# Altium schematic sheet carrier.SchDoc
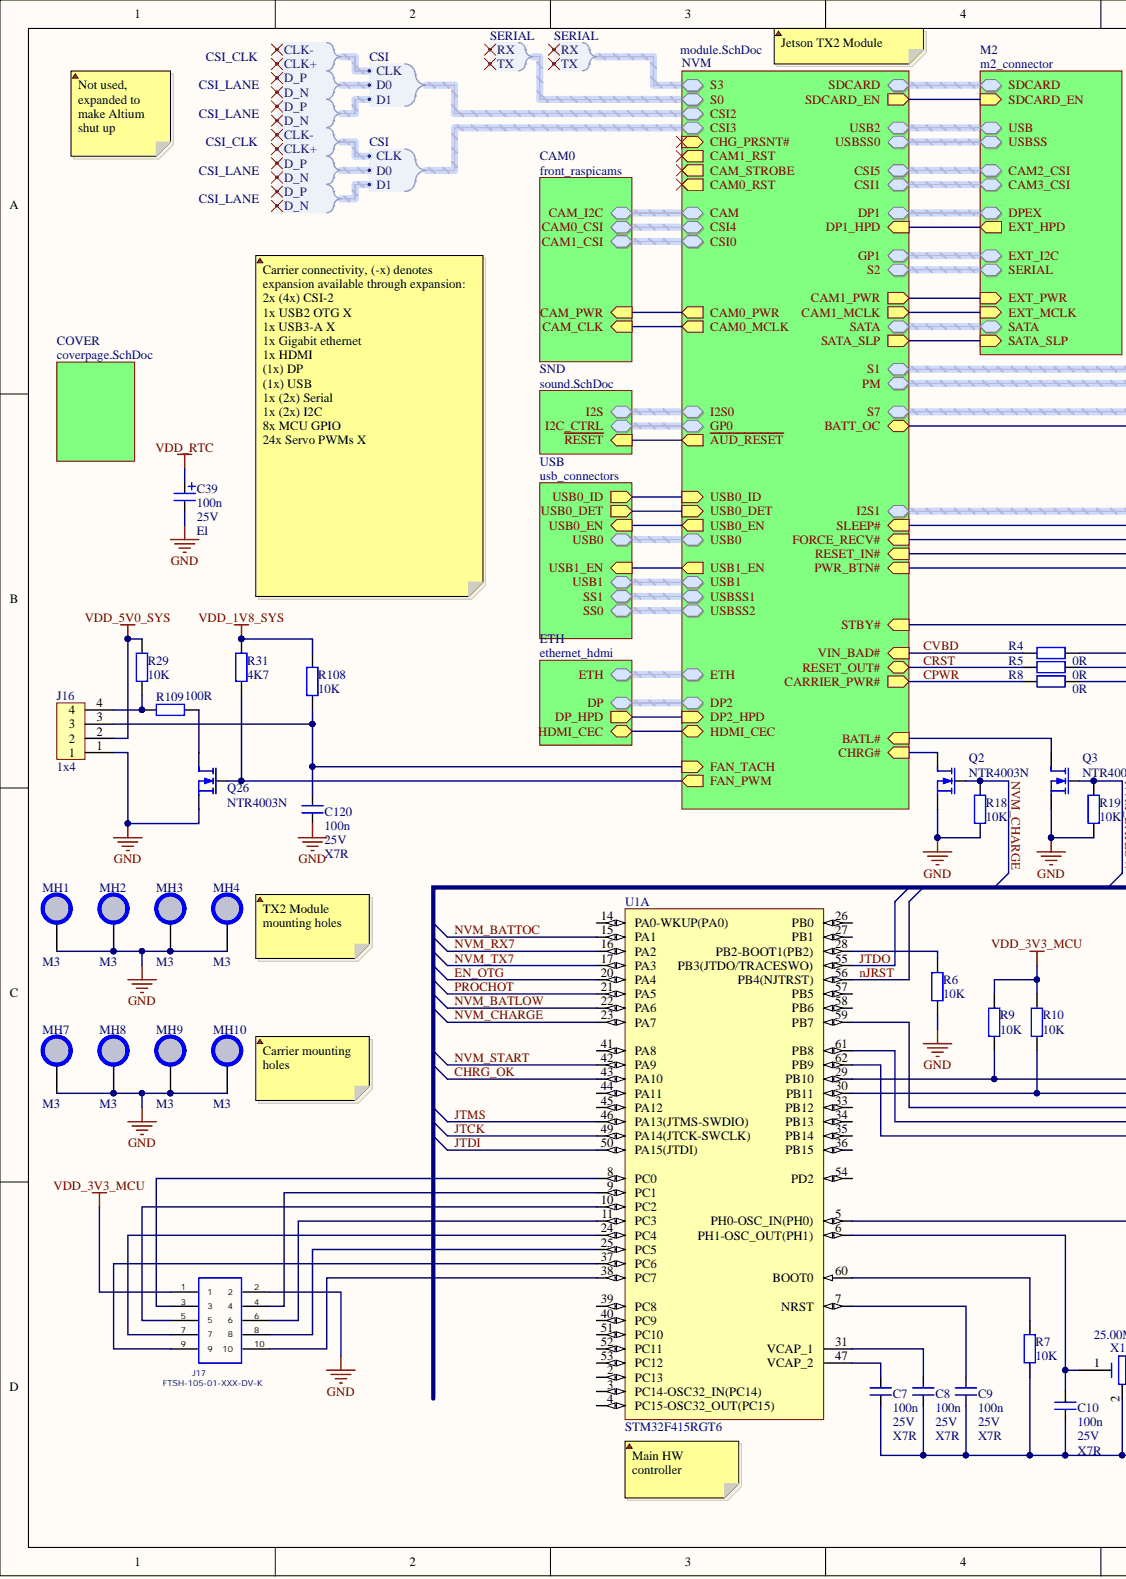
[diagram p0: carrier.SchDoc, left]
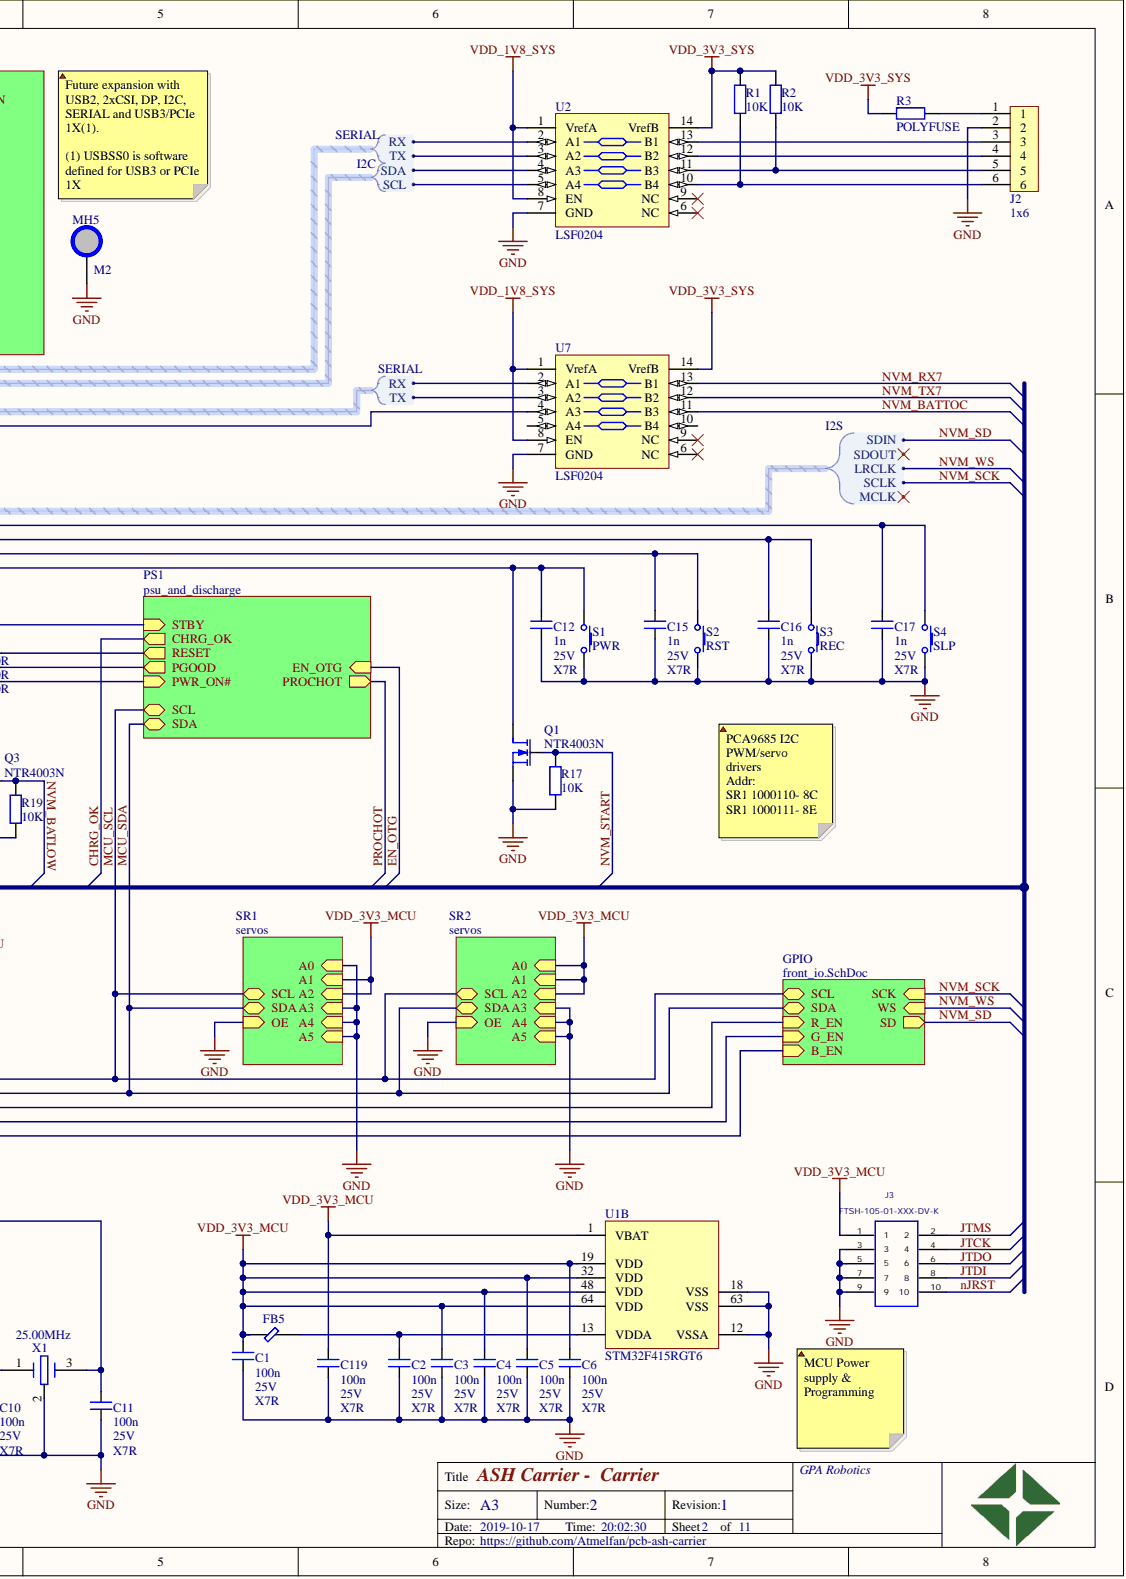
[diagram p1: carrier.SchDoc, right]

## Parts
C1  value 100n  Type: X7R  Voltage: 25V  [C1005[0402]]  (hidden comment: cap)
C2  value 100n  Type: X7R  Voltage: 25V  [C1005[0402]]  (hidden comment: cap)
C3  value 100n  Type: X7R  Voltage: 25V  [C1005[0402]]  (hidden comment: cap)
C4  value 100n  Type: X7R  Voltage: 25V  [C1005[0402]]  (hidden comment: cap)
C5  value 100n  Type: X7R  Voltage: 25V  [C1005[0402]]  (hidden comment: cap)
C6  value 100n  Type: X7R  Voltage: 25V  [C1005[0402]]  (hidden comment: cap)
C7  value 100n  Type: X7R  Voltage: 25V  [C1005[0402]]  (hidden comment: cap)
C8  value 100n  Type: X7R  Voltage: 25V  [C1005[0402]]  (hidden comment: cap)
C9  value 100n  Type: X7R  Voltage: 25V  [C1005[0402]]  (hidden comment: cap)
C10  value 100n  Type: X7R  Voltage: 25V  [C1005[0402]]  (hidden comment: cap)
C11  value 100n  Type: X7R  Voltage: 25V  [C1005[0402]]  (hidden comment: cap)
C12  value 1n  Voltage: 25V  [C1005[0402]]  (hidden comment: cap)
C15  value 1n  Voltage: 25V  [C1005[0402]]  (hidden comment: cap)
C16  value 1n  Voltage: 25V  [C1005[0402]]  (hidden comment: cap)
C17  value 1n  Voltage: 25V  [C1005[0402]]  (hidden comment: cap)
C39  value 100n  Type: El  Voltage: 25V  [SC_DSK-3R3H224U-HL]  (hidden comment: pcap)
C119  value 100n  Type: X7R  Voltage: 25V  [C1005[0402]]  (hidden comment: cap)
C120  value 100n  Type: X7R  Voltage: 25V  [C1005[0402]]  (hidden comment: cap)
FB5  2506036007Y3  [FBC1608X07N]  (value not drawn)
J2  1x6  [hdr_100mil_1x6]
J3  FTSH-105-01-XXX-DV-K  [FTSH-105-01-XXX-DV-K]
J16  1x4  [molex_picoblade_1x4]
J17  FTSH-105-01-XXX-DV-K  [FTSH-105-01-XXX-DV-K]
MH1  M3  [m3_pan_tight_round]
MH2  M3  [m3_pan_tight_round]
MH3  M3  [m3_pan_tight_round]
MH4  M3  [m3_pan_tight_round]
MH5  M2  [m2_pan_tight_round]
MH7  M3  [m3_pan_tight_round]
MH8  M3  [m3_pan_tight_round]
MH9  M3  [m3_pan_tight_round]
MH10  M3  [m3_pan_tight_round]
Q1  NTR4003N  [NCH_SOT95P237X110-3N]
Q2  NTR4003N  [NCH_SOT95P237X110-3N]
Q3  NTR4003N  [NCH_SOT95P237X110-3N]
Q26  NTR4003N  [NCH_SOT95P237X110-3N]
R1  value 10K  [R1005[0402]]  (hidden comment: res)
R2  value 10K  [R1005[0402]]  (hidden comment: res)
R3  value POLYFUSE  [R1005[0402]]  (hidden comment: res)
R4  value 0R  [R1005[0402]]  (hidden comment: res)
R5  value 0R  [R1005[0402]]  (hidden comment: res)
R6  value 10K  [R1005[0402]]  (hidden comment: res)
R7  value 10K  [R1005[0402]]  (hidden comment: res)
R8  value 0R  [R1005[0402]]  (hidden comment: res)
R9  value 10K  [R1005[0402]]  (hidden comment: res)
R10  value 10K  [R1005[0402]]  (hidden comment: res)
R17  value 10K  [R1005[0402]]  (hidden comment: res)
R18  value 10K  [R1005[0402]]  (hidden comment: res)
R19  value 10K  [R1005[0402]]  (hidden comment: res)
R29  value 10K  [R1005[0402]]  (hidden comment: res)
R31  value 4K7  [R1005[0402]]  (hidden comment: res)
R108  value 10K  [R1005[0402]]  (hidden comment: res)
R109  value 100R  [R1005[0402]]  (hidden comment: res)
S1  PWR  [SW_6MM_TACT]
S2  RST  [SW_6MM_TACT]
S3  REC  [SW_6MM_TACT]
S4  SLP  [SW_6MM_TACT]
U1  STM32F415RGT6  [STM-LQFP64_N]  (parts A,B)
U2  LSF0204  [TI_TSOP65P640X120-14N]
U7  LSF0204  [TI_TSOP65P640X120-14N]
X1  value 25.00MHz  [FA-238]  (hidden comment: crystal_shielded)

## Nets
GND: C1.2 C2.2 C3.2 C4.2 C5.2 C6.2 C7.2 C8.2 C9.2 C10.2 C11.2 C12.1 C15.1 C16.1 C17.1 C39.2 C119.2 C120.1 J2.2 J3.3 J3.5 J3.7 J3.9 J16.1 J17.2 MH1.1 MH2.1 MH3.1 MH4.1 MH5.1 MH7.1 MH8.1 MH9.1 MH10.1 Q1.S Q2.S Q3.S Q26.S R6.1 R7.1 R17.1 R18.1 R19.1 S1.2 S2.2 S3.2 S4.2 U1.12(VSSA) U1.18(VSS) U1.63(VSS) U2.7(GND) U7.7(GND) X1.2
VDD_1V8_SYS: R31.2 R108.2 U2.1(VrefA) U2.8(EN) U7.1(VrefA) U7.8(EN)
VDD_3V3_MCU: C1.1 C3.1 C4.1 C5.1 C6.1 C119.1 FB5.1 J3.1 J17.1 R9.2 R10.2 U1.1(VBAT) U1.19(VDD) U1.32(VDD) U1.48(VDD) U1.64(VDD)
VDD_3V3_SYS: R1.2 R2.2 R3.2 U2.14(VrefB) U7.14(VrefB)
VDD_5V0_SYS: J16.2 R29.1
VDD_RTC: C39.1
CHRG_OK: U1.43(PA10)
CPWR: R8.1
CRST: R5.1
CVBD: R4.1
EN_OTG: U1.20(PA4)
JTCK: J3.4 U1.49(PA14(JTCK-SWCLK))
JTDI: J3.8 U1.50(PA15(JTDI))
JTDO: J3.6 U1.55(PB3(JTDO/TRACESWO))
JTMS: J3.2 U1.46(PA13(JTMS-SWDIO))
MCU_SCL: R9.1 U1.29(PB10)
MCU_SDA: R10.1 U1.30(PB11)
nJRST: J3.10 U1.56(PB4(NJTRST))
NVM_BATLOW: Q3.G R19.2 U1.22(PA6)
NVM_BATTOC: U1.15(PA1) U7.11(B3)
NVM_CHARGE: Q2.G R18.2 U1.23(PA7)
NVM_RX7: U1.16(PA2) U7.13(B1)
NVM_START: Q1.G R17.2 U1.42(PA9)
NVM_TX7: U1.17(PA3) U7.12(B2)
PROCHOT: U1.21(PA5)
NetC2_1: C2.1 FB5.2 U1.13(VDDA)
NetC7_1: C7.1 U1.47(VCAP_2)
NetC8_1: C8.1 U1.31(VCAP_1)
NetC9_1: C9.1 U1.7(NRST)
NetC10_1: C10.1 U1.6(PH1-OSC_OUT(PH1)) X1.1
NetC11_1: C11.1 U1.5(PH0-OSC_IN(PH0)) X1.3
NetC12_2: C12.2 Q1.D S1.1
NetC15_2: C15.2 S2.1
NetC16_2: C16.2 S3.1
NetC17_2: C17.2 S4.1
NetC120_2: C120.2 J16.3 R108.1
NetJ2_1: J2.1 R3.1
NetJ2_3: J2.3 U2.13(B1)
NetJ2_4: J2.4 U2.12(B2)
NetJ2_5: J2.5 R2.1 U2.11(B3)
NetJ2_6: J2.6 R1.1 U2.10(B4)
NetJ16_4: J16.4 R29.2 R109.1
NetJ17_3: J17.3 U1.8(PC0)
NetJ17_4: J17.4 U1.9(PC1)
NetJ17_5: J17.5 U1.10(PC2)
NetJ17_6: J17.6 U1.11(PC3)
NetJ17_7: J17.7 U1.24(PC4)
NetJ17_8: J17.8 U1.25(PC5)
NetJ17_9: J17.9 U1.37(PC6)
NetJ17_10: J17.10 U1.38(PC7)
NetQ2_D: Q2.D
NetQ3_D: Q3.D
NetQ26_D: Q26.D R109.2
NetQ26_G: Q26.G R31.1
NetR4_2: R4.2
NetR5_2: R5.2
NetR6_2: R6.2 U1.28(PB2-BOOT1(PB2))
NetR7_2: R7.2 U1.60(BOOT0)
NetR8_2: R8.2
NetU1_2: U1.2(PC13)
NetU1_3: U1.3(PC14-OSC32_IN(PC14))
NetU1_4: U1.4(PC15-OSC32_OUT(PC15))
NetU1_14: U1.14(PA0-WKUP(PA0))
NetU1_26: U1.26(PB0)
NetU1_27: U1.27(PB1)
NetU1_33: U1.33(PB12)
NetU1_34: U1.34(PB13)
NetU1_35: U1.35(PB14)
NetU1_36: U1.36(PB15)
NetU1_39: U1.39(PC8)
NetU1_40: U1.40(PC9)
NetU1_41: U1.41(PA8)
NetU1_44: U1.44(PA11)
NetU1_45: U1.45(PA12)
NetU1_51: U1.51(PC10)
NetU1_52: U1.52(PC11)
NetU1_53: U1.53(PC12)
NetU1_54: U1.54(PD2)
NetU1_57: U1.57(PB5)
NetU1_58: U1.58(PB6)
NetU1_59: U1.59(PB7)
NetU1_61: U1.61(PB8)
NetU1_62: U1.62(PB9)
NetU2_2: U2.2(A1)
NetU2_3: U2.3(A2)
NetU2_4: U2.4(A3)
NetU2_5: U2.5(A4)
NetU2_6: U2.6(NC)
NetU2_9: U2.9(NC)
NetU7_2: U7.2(A1)
NetU7_3: U7.3(A2)
NetU7_4: U7.4(A3)
NetU7_5: U7.5(A4)
NetU7_6: U7.6(NC)
NetU7_9: U7.9(NC)
NetU7_10: U7.10(B4)
~{AUD_RESET}:
CAM0_CSI.CLK.CLK+:
CAM0_CSI.CLK.CLK-:
CAM0_CSI.D0.D_N:
CAM0_CSI.D0.D_P:
CAM0_CSI.D1.D_N:
CAM0_CSI.D1.D_P:
CAM0_RST:
CAM1_CSI.CLK.CLK+:
CAM1_CSI.CLK.CLK-:
CAM1_CSI.D0.D_N:
CAM1_CSI.D0.D_P:
CAM1_CSI.D1.D_N:
CAM1_CSI.D1.D_P:
CAM1_RST:
CAM2_CSI.CLK.CLK+:
CAM2_CSI.CLK.CLK-:
CAM2_CSI.D0.D_N:
CAM2_CSI.D0.D_P:
CAM2_CSI.D1.D_N:
CAM2_CSI.D1.D_P:
CAM3_CSI.CLK.CLK+:
CAM3_CSI.CLK.CLK-:
CAM3_CSI.D0.D_N:
CAM3_CSI.D0.D_P:
CAM3_CSI.D1.D_N:
CAM3_CSI.D1.D_P:
CAM_CLK:
CAM_I2C.SCL:
CAM_I2C.SDA:
CAM_PWR:
CAM_STROBE:
CHG_PRSNT#:
CSI2.CLK.CLK+:
CSI2.CLK.CLK-:
CSI2.D0.D_N:
CSI2.D0.D_P:
CSI2.D1.D_N:
CSI2.D1.D_P:
CSI3.CLK.CLK+:
CSI3.CLK.CLK-:
CSI3.D0.D_N:
CSI3.D0.D_P:
CSI3.D1.D_N:
CSI3.D1.D_P:
DP.AUX.D_N:
DP.AUX.D_P:
DP.TX0.D_N:
DP.TX0.D_P:
DP.TX1.D_N:
DP.TX1.D_P:
DP.TX2.D_N:
DP.TX2.D_P:
DP.TX3.D_N:
DP.TX3.D_P:
DP_HPD:
DPEX.AUX.D_N:
DPEX.AUX.D_P:
DPEX.TX0.D_N:
DPEX.TX0.D_P:
DPEX.TX1.D_N:
DPEX.TX1.D_P:
DPEX.TX2.D_N:
DPEX.TX2.D_P:
DPEX.TX3.D_N:
DPEX.TX3.D_P:
ETH.LINK_100#:
ETH.LINK_1000#:
ETH.LINK_ACT#:
ETH.MDI0.MDI+:
ETH.MDI0.MDI-:
ETH.MDI1.MDI+:
ETH.MDI1.MDI-:
ETH.MDI2.MDI+:
ETH.MDI2.MDI-:
ETH.MDI3.MDI+:
ETH.MDI3.MDI-:
EXT_HPD:
EXT_I2C.SCL:
EXT_I2C.SDA:
EXT_MCLK:
EXT_PWR:
GP0.SCL:
GP0.SDA:
HDMI_CEC:
I2S0.LRCLK:
I2S0.MCLK:
I2S0.SCLK:
I2S0.SDIN:
I2S0.SDOUT:
I2S1.MCLK:
I2S1.SDOUT:
N00091:
NVM_SCK:
NVM_SD:
NVM_WS:
S0.RX:
S0.TX:
S3.RX:
S3.TX:
SATA.RX.D_N:
SATA.RX.D_P:
SATA.TX.D_N:
SATA.TX.D_P:
SATA_SLP:
SDCARD.CD:
SDCARD.CLK:
SDCARD.CMD:
SDCARD.D0:
SDCARD.D1:
SDCARD.D2:
SDCARD.D3:
SDCARD.WP:
SDCARD_EN:
SERIAL.RX:
SERIAL.TX:
STBY#:
USB0.D_N:
USB0.D_P:
USB0_DET:
USB0_EN:
USB0_ID:
USB1.D_N:
USB1.D_P:
USB1_EN:
USB.D_N:
USB.D_P:
USBSS1.SS_RX.SS_N:
USBSS1.SS_RX.SS_P:
USBSS1.SS_TX.SS_N:
USBSS1.SS_TX.SS_P:
USBSS2.SS_RX.SS_N:
USBSS2.SS_RX.SS_P:
USBSS2.SS_TX.SS_N:
USBSS2.SS_TX.SS_P:
USBSS.SS_RX.SS_N:
USBSS.SS_RX.SS_P:
USBSS.SS_TX.SS_N:
USBSS.SS_TX.SS_P:

## Library data of the parts
C1-C11 C119 C120 = cap: Capacitor; Component Kind: Standard; Library Name: gpa_passives.SchLib
C12 C15-C17 = cap: Capacitor; Type: X7R; Component Kind: Standard; Library Name: gpa_passives.SchLib
C39 = pcap: Polarized capacitor; Component Kind: Standard; Library Name: gpa_passives.SchLib
FB5 = ferrite_bead: Ferrite bead; Component Kind: Standard; Library Name: gpa_passives.SchLib
J2 = header_1x6: Component Kind: Standard; Library Name: gpa_connectors.SchLib
J3 J17 = FTSH-105-01-XXX-DV-K: TERMINAL STRIP, .050"(1.27mm) PITCH, 10 PINS, KEYED; MANUFACTURER: SAMTEC; Component Kind: Standard; Library Name: FTSH-105-01-XXX-DV-K.IntLib; PCB DECAL: FTSH-105-01-XXX-DV-K; CREATED: November 30, 2016 - Wendy Xin
J16 = header_1x4: Component Kind: Standard; Library Name: gpa_connectors.SchLib
MH1-MH5 MH7-MH10 = mounting_hole: Component Kind: Standard; Library Name: gpa_mounting.SchLib
Q1-Q3 Q26 = nch_mosfet: Component Kind: Standard; Library Name: gpa_actives.SchLib
R1-R10 R17-R19 = res: Resistor; Component Kind: Standard; Library Name: gpa_passives.SchLib
R29 R31 R108 R109 = res: Resistor; Tolerance: 5%; Component Kind: Standard; Library Name: gpa_passives.SchLib
S1-S4 = btn: Component Kind: Standard; Library Name: gpa_passives.SchLib
  S1: Text: PWR
  S2: Text: RST
  S3: Text: REC
  S4: Text: SLP
U1 = STM32F415RGT6: ARM Cortex-M4 32-bit MCU+FPU, 210 DMIPS, 1024 kB Flash, 192 kB Internal RAM, 51 I/Os, 64-pin LQFP, -40 to 85 degC, Tray; Manufacturer: STMicroelectronics; D/A Converter: 2x12-bit; FLASH Size (Prog) (kB): 1024; I/Os (High Current): 51; Internal RAM Size (kB): 192; Operating Frequency(F) (Processor speed) (MHz): 168; Supply Voltage(Vcc) max (V): 3.6; Supply Voltage(Vcc) min (V): 1.8; Component Kind: Standard; ComponentLink1Description: Manufacturer URL; ComponentLink1URL: http://www.st.com/web/en/home.html; ComponentLink2Description: Datasheet; ComponentLink2URL: http://www.st.com/st-web-ui/static/active/en/resource/technical/document/datasheet/DM00035129.pdf; ComponentLink3Description: Package Specification; ComponentLink3URL: http://www.st.com/st-web-ui/static/active/en/resource/technical/document/datasheet/DM00037051.pdf; Core: ARM Cortex-M4; DatasheetVersion: Rev. 4; Library Name: STMicroelectronics STM32 F4.IntLib; Mounting Technology: Surface Mount; PackageDescription: 64-Pin Low Profile Quad Flat Package, 10 x 10 mm Body, 0.5 mm Pitch; PackageReference: LQFP64; PackageVersion: Rev. 4, 06/2013; Packing: Tray; PartNumber: STM32F415RGT6; Supplier 1: Digi-Key; Supplier 2: Farnell; Supplier 3: Newark; Supplier 4: Mouser; Supplier Part Number 1: 497-11907-ND; Supplier Part Number 2: 2064370; Supplier Part Number 3: 73T2335; Supplier Part Number 4: 511-STM32F415RGT6
X1 = crystal_shielded: Crystal with shield; Component Kind: Standard; Library Name: gpa_passives.SchLib
U2 U7 = lsf0204: Component Kind: Standard; Library Name: gpa_ti.SchLib
